# Altium schematic sheet Power Supply.SchDoc("Power Supply")
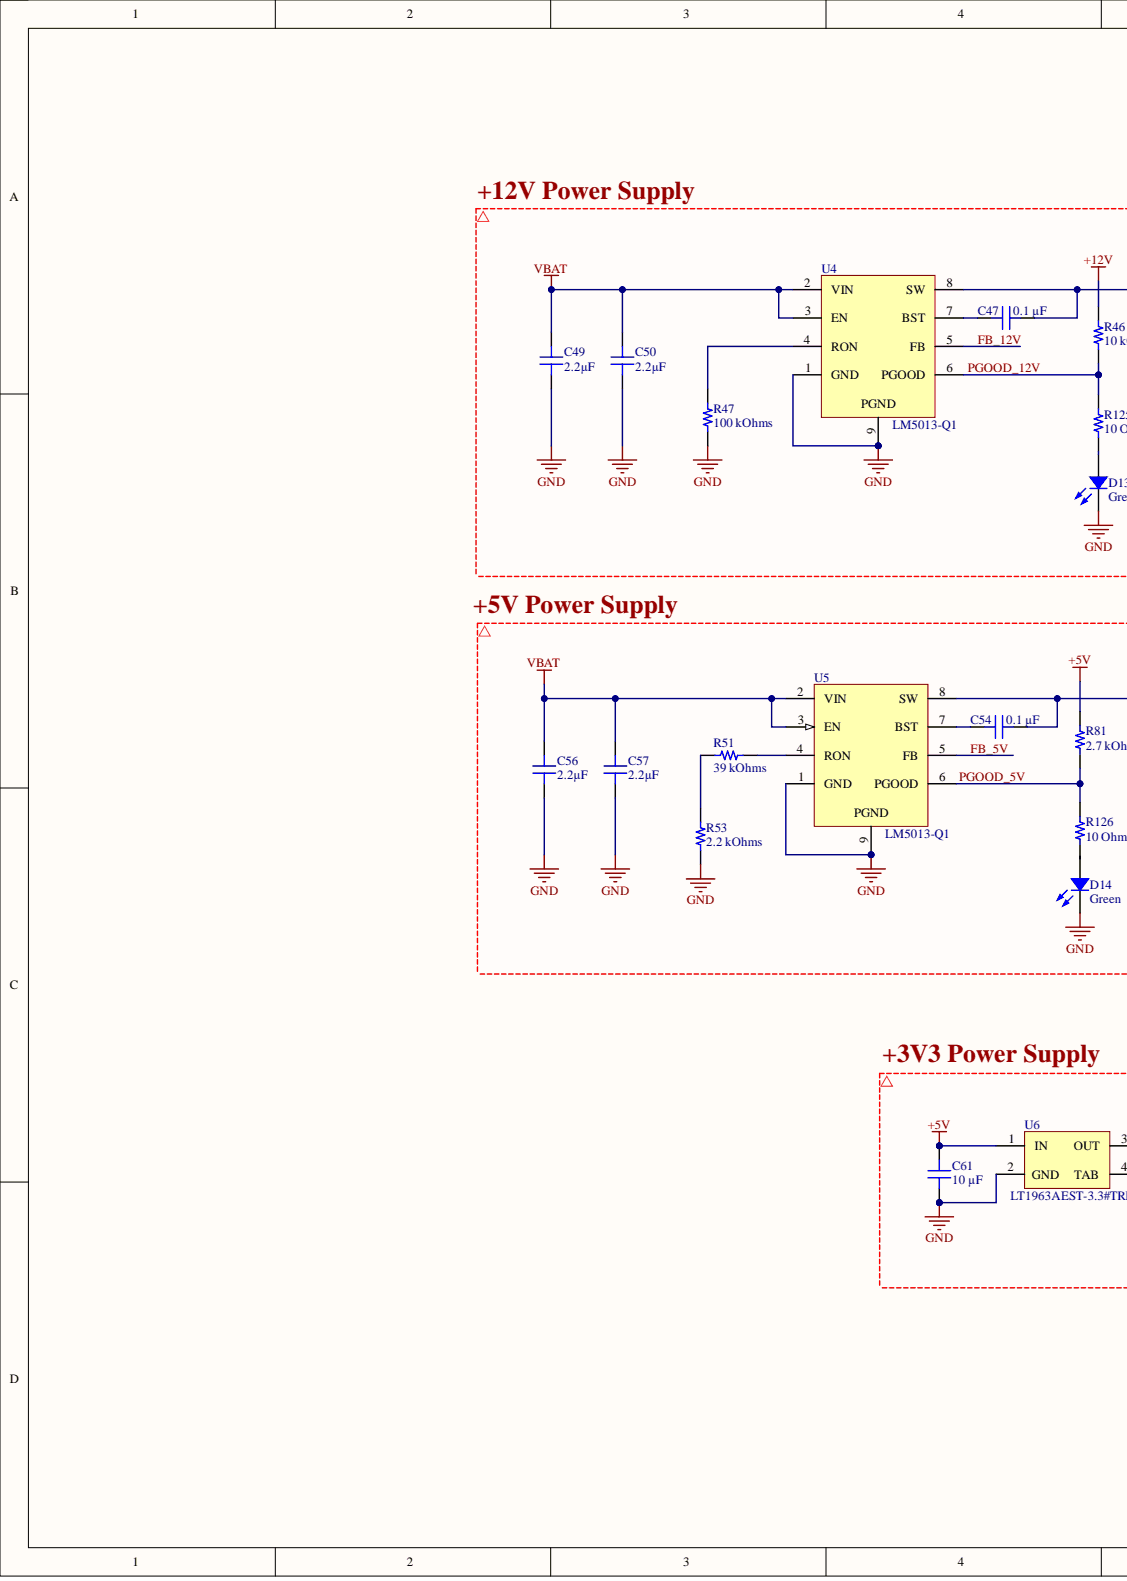
[diagram p0: Power Supply.SchDoc, left]
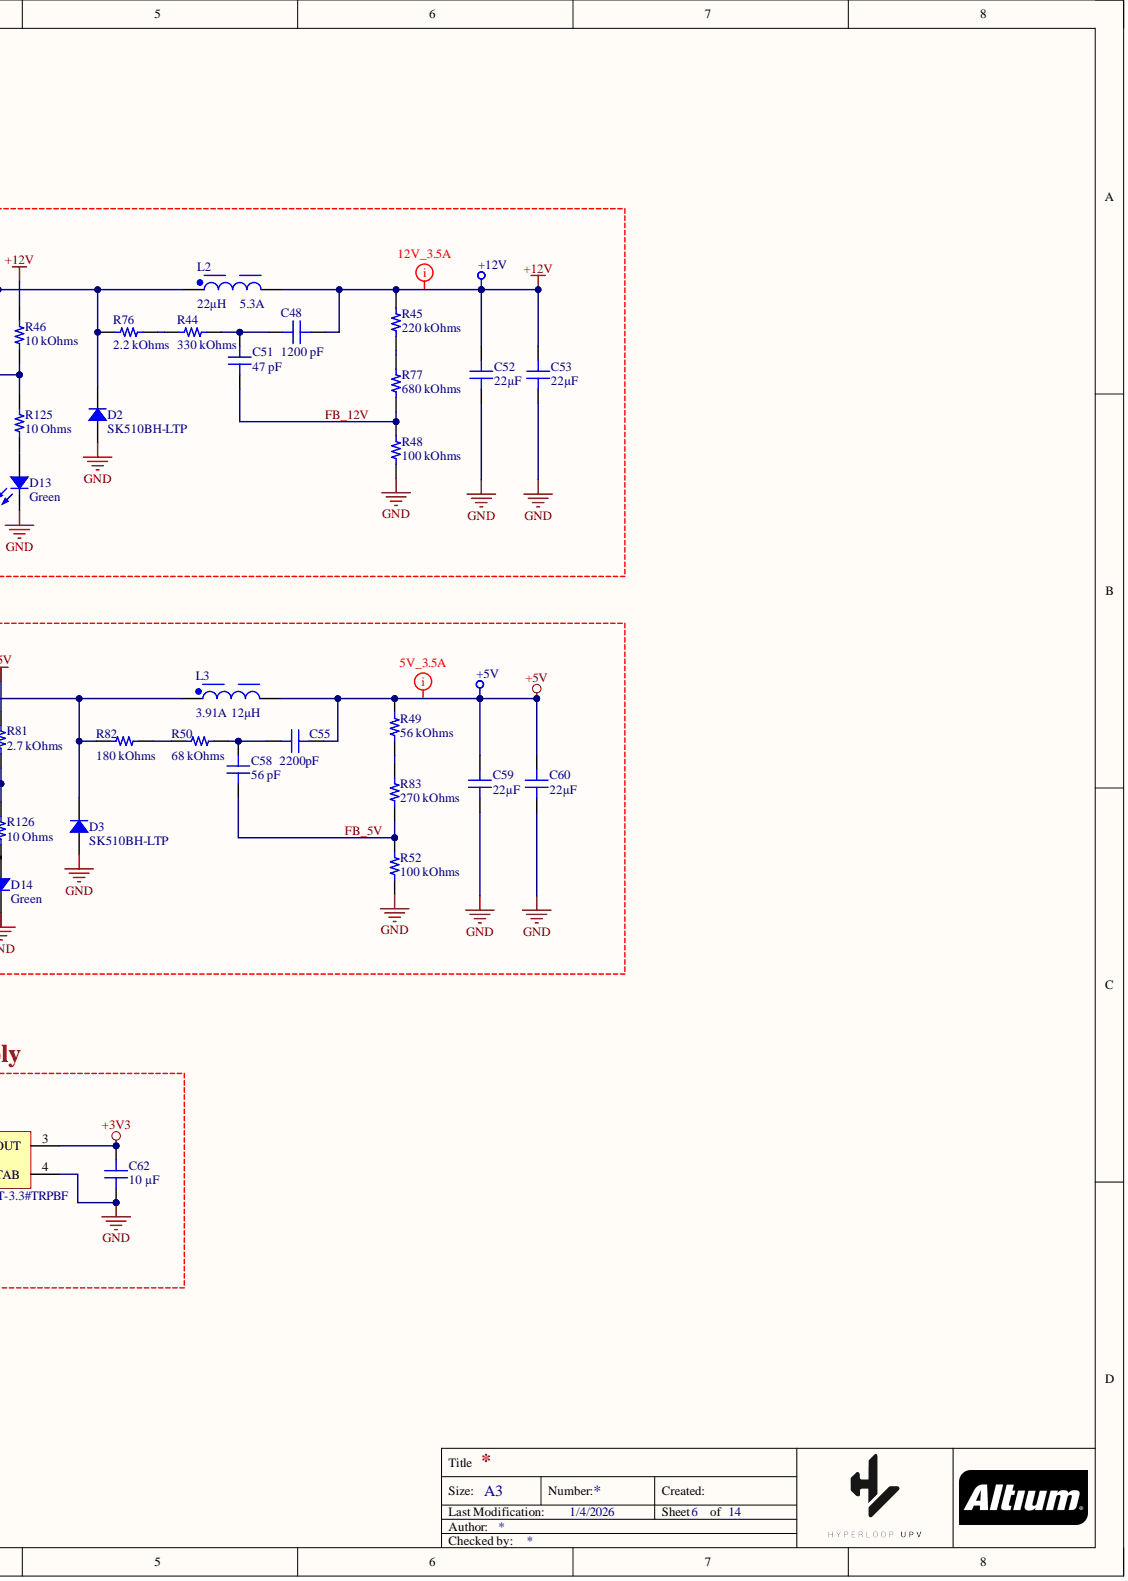
[diagram p1: Power Supply.SchDoc, right]

## Parts
+5V  Test point 1.2mm  (value not drawn)
+12V  Test point 1.2mm  (value not drawn)
C47  0.1 µF
C48  1200 pF
C49  2.2µF
C50  2.2µF
C51  47 pF
C52  22µF
C53  22µF
C54  0.1 µF
C55  2200pF
C56  2.2µF
C57  2.2µF
C58  56 pF
C59  22µF
C60  22µF
C61  10 µF
C62  10 µF
D2  SK510BH-LTP
D3  SK510BH-LTP
D13  Green
D14  Green
L2  Inductance: 22µH  Rated Current: 5.3A  (hidden comment: 7447709220)
L3  Inductance: 12µH  Rated Current: 3.91A  (hidden comment: 744771112)
R44  330 kOhms
R45  220 kOhms
R46  10 kOhms
R47  100 kOhms
R48  100 kOhms
R49  56 kOhms
R50  68 kOhms
R51  39 kOhms
R52  100 kOhms
R53  2.2 kOhms
R76  2.2 kOhms
R77  680 kOhms
R81  2.7 kOhms
R82  180 kOhms
R83  270 kOhms
R125  10 Ohms
R126  10 Ohms
U4  LM5013-Q1
U5  LM5013-Q1
U6  LT1963AEST-3.3#TRPBF

## Nets
+3V3: C62.2 U6.3(OUT)
+5V: +5V.1 C55.2 C59.2 C60.2 C61.2 L3.2 R49.2 R81.2 U6.1(IN)
+12V: +12V.1 C48.2 C52.2 C53.2 L2.2 R45.2 R46.2
GND: C49.1 C50.1 C52.1 C53.1 C56.1 C57.1 C59.1 C60.1 C61.1 C62.1 D2.1 D3.1 D13.2 D14.2 R47.1 R48.1 R52.1 R53.1 U4.1(GND) U4.9 U5.1(GND) U5.9 U6.2(GND) U6.4(TAB)
VBAT: C49.2 C50.2 C56.2 C57.2 U4.2(VIN) U4.3(EN) U5.2(VIN) U5.3(EN)
FB_5V: C58.1 R52.2 R83.1 U5.5(FB)
FB_12V: C51.1 R48.2 R77.1 U4.5(FB)
PGOOD_5V: R81.1 R126.2 U5.6(PGOOD)
PGOOD_12V: R46.1 R125.2 U4.6(PGOOD)
NetC47_1: C47.1 U4.7(BST)
NetC47_2: C47.2 D2.2 L2.1 R76.1 U4.8(SW)
NetC48_1: C48.1 C51.2 R44.2
NetC54_1: C54.1 U5.7(BST)
NetC54_2: C54.2 D3.2 L3.1 R82.1 U5.8(SW)
NetC55_1: C55.1 C58.2 R50.2
NetD13_1: D13.1 R125.1
NetD14_1: D14.1 R126.1
NetR44_1: R44.1 R76.2
NetR45_1: R45.1 R77.2
NetR47_2: R47.2 U4.4(RON)
NetR49_1: R49.1 R83.2
NetR50_1: R50.1 R82.2
NetR51_1: R51.1 U5.4(RON)
NetR51_2: R51.2 R53.2

## Library data of the parts
+5V +12V = CMP-006-00250-2: 1.2mm Test point; Component Kind: Standard; Library Name: Hyperloop UPV
C47 C54 = C0603X104K101T: CAP CER .1UF 100V X7R 0603; manufacturer: Holy Stone Enterprise Co., Ltd.; Database Table Name: Capacitors - Ceramic - 0603; Tolerance: ±10%; Applications: General Purpose; Capacitance: 0.1 µF; Component Kind: Standard; componentlink1description: Datasheet; componentlink1url: https://www.holystonecaps.com/PDF/201612051332320.2017%20MVC%20Series.pdf; componentlink2description: DigiKey Link; componentlink2url: https://www.digikey.com/en/products/detail/holy-stone-enterprise-co-ltd/C0603X104K101T/13152144; Device Package: 0603 (1608 Metric); Library Name: Celestial Altium Library - altium_library.DbLib; Lifecycle Status: Active; Minimum Order: 1; Mounting Type: Surface Mount, MLCC; Operating Temperature: -55°C ~ 125°C; Package / Case: 0603 (1608 Metric); packaging: TapeAndReel; Part Number: C0603X104K101T; Part Status: Active; partid: 34785; price: 0.03; series: MVC; Size / Dimension: 0.063" L x 0.031" W (1.60mm x 0.80mm); Supplier 1: DigiKey; Supplier Part Number 1: 2873-C0603X104K101TCT-ND; Temperature Coefficient: X7R; Thickness (Max): 0.039" (1.00mm); Value: 0.1 µF; Voltage - Rated: 100V
  C47: lastupdated: 19/09/2022 13:00:08
  C54: lastupdated: 2/9/2024 8:09:55 PM
C48 = C0603C122K1RAC7867: CAP CER 1200PF 100V X7R 0603; manufacturer: KEMET; Database Table Name: Capacitors - Ceramic - 0603; Tolerance: ±10%; Applications: Bypass, Decoupling; Capacitance: 1200 pF; Component Kind: Standard; componentlink1description: Datasheet; componentlink1url: https://api.kemet.com/component-edge/download/datasheet/C0603C122K1RACTU.pdf; componentlink2description: DigiKey Link; componentlink2url: https://www.digikey.com/en/products/detail/kemet/C0603C122K1RAC7867/2199865; Device Package: 0603 (1608 Metric); lastupdated: 19/09/2022 13:01:19; Library Name: Celestial Altium Library - altium_library.DbLib; Lifecycle Status: Active; Minimum Order: 1; Mounting Type: Surface Mount, MLCC; Operating Temperature: -55°C ~ 125°C; Package / Case: 0603 (1608 Metric); packaging: TapeAndReel; Part Number: C0603C122K1RAC7867; Part Status: Active; partid: 2381; price: 0.06; series: SMD Comm X7R; Size / Dimension: 0.063" L x 0.031" W (1.60mm x 0.80mm); Supplier 1: DigiKey; Supplier Part Number 1: 399-15358-1-ND; Temperature Coefficient: X7R; Thickness (Max): 0.034" (0.87mm); Value: 1200 pF; Voltage - Rated: 100V
C49 C50 C56 C57 = 12061C225KAT4A: CAP CER 2.2UF 100V X7R 1206; manufacturer: AVX Corporation; Database Table Name: Capacitors - Ceramic - 1206; Tolerance: ±10%; Applications: General Purpose; Capacitance: 2.2µF; Component Kind: Standard; componentlink1description: Datasheet; componentlink1url: http://datasheets.avx.com/X7RDielectric.pdf; componentlink2description: DigiKey Link; componentlink2url: http://digikey.com/product-detail/en/avx-corporation/12061C225KAT4A/478-12481-1-ND/9749333; Device Package: 1206 (3216 Metric); Library Name: Celestial Altium Library - altium_library.DbLib; Lifecycle Status: Active; Minimum Order: 1; Mounting Type: Surface Mount, MLCC; Operating Temperature: -55°C ~ 125°C; Package / Case: 1206 (3216 Metric); packaging: TapeAndReel; Part Number: 12061C225KAT4A; Part Status: Active; partid: 20152; price: 0.13; series: -; Size / Dimension: 0.126" L x 0.063" W (3.20mm x 1.60mm); Supplier 1: DigiKey; Supplier Part Number 1: 478-12481-1-ND; Temperature Coefficient: X7R; Thickness (Max): 0.060" (1.52mm); Value: 2.2µF; Voltage - Rated: 100V
  C49 C50: lastupdated: 02/09/2020 1:05:40
  C56 C57: lastupdated: 9/2/2020 1:05:40 AM
C51 C58 = GQM1875C2E560JB12D: CAP CER 56PF 250V C0G/NP0 0603; manufacturer: Murata Electronics; Database Table Name: Capacitors - Ceramic - 0603; Temperature Coefficient: C0G, NP0; Tolerance: ±5%; Applications: RF, Microwave, High Frequency; Capacitance: 56 pF; Component Kind: Standard; componentlink1description: Datasheet; componentlink1url: https://www.murata.com/~/media/webrenewal/support/library/catalog/products/capacitor/mlcc/c02e.ashx?la=en-us; componentlink2description: DigiKey Link; componentlink2url: https://www.digikey.com/en/products/detail/murata-electronics/GQM1875C2E560JB12D/3900478; Device Package: 0603 (1608 Metric); Features: High Q, Low Loss; lastupdated: 7/20/2024 12:19:27 AM; Library Name: Celestial Altium Library - altium_library.DbLib; Lifecycle Status: Active; Minimum Order: 1; Mounting Type: Surface Mount, MLCC; Operating Temperature: -55°C ~ 125°C; Package / Case: 0603 (1608 Metric); packaging: TapeAndReel; Part Number: GQM1875C2E560JB12D; Part Status: Active; partid: 9090; price: 0.08; series: GQM; Size / Dimension: 0.063" L x 0.031" W (1.60mm x 0.80mm); Supplier 1: DigiKey; Supplier Part Number 1: 490-7175-1-ND; Thickness (Max): 0.031" (0.80mm); Voltage - Rated: 250V
  C51: Value: 47 pF
  C58: Value: 56 pF
C52 C53 C59 C60 = 12063D226KAT2A: CAP CER 22UF 25V X5R 1206; manufacturer: AVX Corporation; Database Table Name: Capacitors - Ceramic - 1206; Tolerance: ±10%; Applications: General Purpose; Capacitance: 22µF; Component Kind: Standard; componentlink1description: Datasheet; componentlink1url: http://datasheets.avx.com/cx5r.pdf; componentlink2description: DigiKey Link; componentlink2url: http://digikey.com/product-detail/en/avx-corporation/12063D226KAT2A/478-9946-1-ND/6149054; Device Package: 1206 (3216 Metric); Library Name: Celestial Altium Library - altium_library.DbLib; Lifecycle Status: Active; Minimum Order: 1; Mounting Type: Surface Mount, MLCC; Operating Temperature: -55°C ~ 85°C; Package / Case: 1206 (3216 Metric); packaging: TapeAndReel; Part Number: 12063D226KAT2A; Part Status: Active; partid: 21243; price: 0.38; series: -; Size / Dimension: 0.126" L x 0.063" W (3.20mm x 1.60mm); Supplier 1: DigiKey; Supplier Part Number 1: 478-9946-1-ND; Temperature Coefficient: X5R; Thickness (Max): 0.071" (1.80mm); Value: 22µF; Voltage - Rated: 25V
  C52 C53: lastupdated: 02/09/2020 1:05:36
  C59 C60: lastupdated: 9/2/2020 1:05:36 AM
C55 = 06035A222JAT2A: CAP CER 2200PF 50V C0G/NP0 0603; manufacturer: AVX Corporation; Database Table Name: Capacitors - Ceramic - 0603; Temperature Coefficient: C0G, NP0; Tolerance: ±5%; Applications: General Purpose; Capacitance: 2200pF; Component Kind: Standard; componentlink1description: Datasheet; componentlink1url: http://datasheets.avx.com/C0GNP0-Dielectric.pdf; componentlink2description: DigiKey Link; componentlink2url: http://digikey.com/product-detail/en/avx-corporation/06035A222JAT2A/478-12698-1-ND/9949232; Device Package: 0603 (1608 Metric); lastupdated: 9/2/2020 1:02:59 AM; Library Name: Celestial Altium Library - altium_library.DbLib; Lifecycle Status: Active; Minimum Order: 1; Mounting Type: Surface Mount, MLCC; Operating Temperature: -55°C ~ 125°C; Package / Case: 0603 (1608 Metric); packaging: TapeAndReel; Part Number: 06035A222JAT2A; Part Status: Active; partid: 18282; price: 0.10; series: -; Size / Dimension: 0.063" L x 0.032" W (1.60mm x 0.81mm); Supplier 1: DigiKey; Supplier Part Number 1: 478-12698-1-ND; Thickness (Max): 0.035" (0.90mm); Value: 2200pF; Voltage - Rated: 50V
C61 C62 = C1206X106J3RACAUTO: CAP CER 10UF 25V X7R 1206; manufacturer: KEMET; Database Table Name: Capacitors - Ceramic - 1206; Tolerance: ±5%; Applications: Automotive, Boardflex Sensitive; Capacitance: 10 µF; Component Kind: Standard; componentlink1description: Datasheet; componentlink1url: https://api.kemet.com/component-edge/download/datasheet/C1206X106J3RACAUTO.pdf; componentlink2description: DigiKey Link; componentlink2url: https://www.digikey.com/en/products/detail/kemet/C1206X106J3RACAUTO/7427566; Device Package: 1206 (3216 Metric); Features: Soft Termination; lastupdated: 6/4/2024 7:10:37 PM; Library Name: Celestial Altium Library - altium_library.DbLib; Lifecycle Status: Active; Minimum Order: 1; Mounting Type: Surface Mount, MLCC; Operating Temperature: -55°C ~ 125°C; Package / Case: 1206 (3216 Metric); packaging: TapeAndReel; Part Number: C1206X106J3RACAUTO; Part Status: Active; partid: 46968; price: 0.43; Ratings: AEC-Q200; series: SMD Auto X7R Flex; Size / Dimension: 0.130" L x 0.063" W (3.30mm x 1.60mm); Supplier 1: DigiKey; Supplier Part Number 1: 399-15736-1-ND; Temperature Coefficient: X7R; Thickness (Max): 0.077" (1.95mm); Value: 10 µF; Voltage - Rated: 25V
D2 D3 = SK510BH-LTP: 5A 100V SCHOTTKY SMB; Manufacturer: Micro Commercial Co; Current - Reverse Leakage @ Vr: 5µA @ 100V; Database Table Name: Diodes - Rectifiers - Single; Speed: Fast Recovery =< 500ns, > 200mA (Io); Voltage - Forward (Vf) (Max) @ If: 850mV @ 5A; Component Kind: Standard; ComponentLink2Description: DigiKey Link; ComponentLink2URL: http://digikey.com/product-detail/en/micro-commercial-co/SK510BH-LTP/353-SK510BH-LTPCT-ND/12177332; Current - Average Rectified (Io): 5A; Diode Type: Schottky; Library Name: Celestial Altium Library - altium_library.DbLib; Lifecycle Status: Active; Minimum Order: 1; Mounting Type: Surface Mount; Package / Case: DO-214AA, SMB; Packaging: TapeAndReel; Part Number: SK510BH-LTP; PartId: 3326; Price: 0.08; Supplier 1: DigiKey; Supplier Device Package: DO-214AA (SMB); Supplier Part Number 1: 353-SK510BH-LTPCT-ND; Voltage - DC Reverse (Vr) (Max): 100V
  D2: LastUpdated: 30/08/2020 20:59:21
  D3: LastUpdated: 8/30/2020 8:59:21 PM
D13 D14 = VLMTG1300-GS08: LED GREEN 0603 SMD; Manufacturer: Vishay Semiconductor Opto Division; Configuration: Standard; Current - Test: 20mA; Database Table Name: LED - Indication; Lens Color: 1.00mm; Lens Size: 1.10mm x 0.80mm; Lens Style: Rectangle with Flat Top; Millicandela Rating: 260.5mcd; Viewing Angle: 130°; Voltage - Forward (Vf) (Typ): 3.2V; Wavelength - Dominant: 525nm; Wavelength - Peak: 530nm; Color: Green; Component Kind: Standard; ComponentLink1Description: Datasheet; ComponentLink1URL: http://www.vishay.com/docs/82437/vlmo1300.pdf; ComponentLink2Description: DigiKey Link; ComponentLink2URL: https://www.digikey.com/en/products/detail/vishay-semiconductor-opto-division/VLMTG1300-GS08/2813351; Device Package: 0603 (1608 Metric); Height (Max): 1.00mm; LastUpdated: 19/09/2022 13:49:58; Library Name: Celestial Altium Library - altium_library.DbLib; Lifecycle Status: Active; Minimum Order: 1; Mounting Type: Surface Mount; Package / Case: 0603 (1608 Metric); Packaging: TapeAndReel; Part Number: VLMTG1300-GS08; Part Status: Active; PartId: 609; Price: 0.08; Series: CHIPLED; Size / Dimension: 1.60mm L x 0.80mm W; Supplier 1: DigiKey; Supplier Device Package: 0603; Supplier Part Number 1: VLMTG1300-GS08CT-ND; Value: Green
L2 = CMP-006-00206-1: WE-PD SMT Power Inductor, size 1210, 22µH, 5.3A, 28mO; Manufacturer: Wurth Elektronik; DC Resistance: 23mO; Saturation Current: 6.5A; Self Resonant Frequency: 10MHz; Type: Standard; Case/Package: 1210; Category: Shielded Power Inductors; Component Kind: Standard; Component Type: Standard; ComponentLink1Description: Website Link; ComponentLink1URL: https://www.we-online.com/redexpert/article/7447709220?ad; ComponentLink2Description: Datasheet Link; ComponentLink2URL: https://www.we-online.com/redexpert/spec/7447709220?ad; DC Resistance Max: 28mO; Design Item ID: 7447709220; Height: 10mm; Length: 12mm; Manufacturer Part Number: 7447709220; Match Code: WE-PD; Mount: Surface Mount; Operating Temperature Max: 125°C; Operating Temperature Min: -40°C; Packaging: Tape and Reel; Pins: 2; Source: WE-PD.SchLib; Width: 12mm; Library Name: Hyperloop UPV
L3 = CMP-006-00200-1: WE-PD SMT Power Inductor, size 1260, 12µH, 3.91A, 27mO; Manufacturer: Wurth Elektronik; DC Resistance: 23mO; Saturation Current: 4.85A; Self Resonant Frequency: 19.7MHz; Type: Standard; Case/Package: 1260; Category: Shielded Power Inductors; Component Kind: Standard; Component Type: Standard; ComponentLink1Description: Website Link; ComponentLink1URL: https://www.we-online.com/redexpert/article/744771112?ad; ComponentLink2Description: Datasheet Link; ComponentLink2URL: https://www.we-online.com/redexpert/spec/744771112?ad; DC Resistance Max: 27mO; Design Item ID: 744771112; Height: 6mm; Length: 12mm; Manufacturer Part Number: 744771112; Match Code: WE-PD; Mount: Surface Mount; Operating Temperature Max: 125°C; Operating Temperature Min: -40°C; Packaging: Tape and Reel; Pins: 2; Source: WE-PD.SchLib; Width: 12mm; Library Name: Hyperloop UPV
R44 R76 = RK73H1JTTD3323F: RES 332K OHM 1% 1/10W 0603; Manufacturer: KOA Speer Electronics, Inc.; Database Table Name: Resistors - Surface Mount - 0603; Power (Watts): 0.1W, 1/10W; Resistance: 332 kOhms; Temperature Coefficient: ±100ppm/°C; Component Kind: Standard; ComponentLink1Description: Datasheet; ComponentLink1URL: http://www.koaspeer.com/catimages/Products/RK73H/RK73H.pdf; ComponentLink2Description: DigiKey Link; ComponentLink2URL: https://www.digikey.com/en/products/detail/koa-speer-electronics-inc/RK73H1JTTD3323F/9844963; Composition: Thick Film; Device Package: 0603 (1608 Metric); Features: Automotive AEC-Q200; Height - Seated (Max): 0.022" (0.55mm); LastUpdated: 7/25/2024 9:43:09 AM; Library Name: Celestial Altium Library - altium_library.DbLib; Lifecycle Status: Active; Minimum Order: 1; Number of Terminations: 2; Operating Temperature: -55°C ~ 155°C; Package / Case: 0603 (1608 Metric); Packaging: CutTape; Part Number: RK73H1JTTD3323F; Part Status: Active; PartId: 6126; Price: 0.01; Ratings: AEC-Q200; Series: RK73H; Size / Dimension: 0.063" L x 0.031" W (1.60mm x 0.80mm); Supplier 1: DigiKey; Supplier Device Package: 0603; Supplier Part Number 1: 2019-RK73H1JTTD3323FCT-ND; Tolerance: ±1%
  R44: Value: 330 kOhms
  R76: Value: 2.2 kOhms
R45 R77 = CRCW0603909KFKEA: RES SMD 909K OHM 1% 1/10W 0603; Manufacturer: Vishay Dale; Database Table Name: Resistors - Surface Mount - 0603; Power (Watts): 0.1W, 1/10W; Resistance: 909 kOhms; Temperature Coefficient: ±100ppm/°C; Component Kind: Standard; ComponentLink1Description: Datasheet; ComponentLink1URL: http://www.vishay.com/docs/20035/dcrcwe3.pdf; ComponentLink2Description: DigiKey Link; ComponentLink2URL: https://www.digikey.com/en/products/detail/vishay-dale/CRCW0603909KFKEA/1175005; Composition: Thick Film; Device Package: 0603 (1608 Metric); Features: Automotive AEC-Q200; Height - Seated (Max): 0.020" (0.50mm); LastUpdated: 2/9/2024 8:43:24 PM; Library Name: Celestial Altium Library - altium_library.DbLib; Lifecycle Status: Active; Minimum Order: 1; Number of Terminations: 2; Operating Temperature: -55°C ~ 155°C; Package / Case: 0603 (1608 Metric); Packaging: TapeAndReel; Part Number: CRCW0603909KFKEA; Part Status: Active; PartId: 8009; Price: 0.01; Ratings: AEC-Q200; Series: CRCW; Size / Dimension: 0.061" L x 0.033" W (1.55mm x 0.85mm); Supplier 1: DigiKey; Supplier Device Package: 0603; Supplier Part Number 1: 541-909KHCT-ND; Tolerance: ±1%
  R45: Value: 220 kOhms
  R77: Value: 680 kOhms
R46 = RT0603FRE0710KL: RES SMD 10K OHM 1% 1/10W 0603; Manufacturer: Yageo; Database Table Name: Resistors - Surface Mount - 0603; Power (Watts): 0.1W, 1/10W; Temperature Coefficient: ±50ppm/°C; Component Kind: Standard; ComponentLink1Description: Datasheet; ComponentLink1URL: https://www.yageo.com/upload/media/product/productsearch/datasheet/rchip/PYu-RT_1-to-0.01_RoHS_L_11.pdf; ComponentLink2Description: DigiKey Link; ComponentLink2URL: https://www.digikey.com/en/products/detail/yageo/RT0603FRE0710KL/1075040; Composition: Thin Film; Device Package: 0603 (1608 Metric); Height - Seated (Max): 0.022" (0.55mm); LastUpdated: 7/25/2024 9:19:32 AM; Library Name: Celestial Altium Library - altium_library.DbLib; Lifecycle Status: Active; Minimum Order: 1; Number of Terminations: 2; Operating Temperature: -55°C ~ 155°C; Package / Case: 0603 (1608 Metric); Packaging: TapeAndReel; Part Number: RT0603FRE0710KL; Part Status: Active; PartId: 5671; Price: 0.01; Resistance: 10 kOhms; Series: RT; Size / Dimension: 0.063" L x 0.031" W (1.60mm x 0.80mm); Supplier 1: DigiKey; Supplier Device Package: 0603; Supplier Part Number 1: YAG2321CT-ND; Tolerance: ±1%; Value: 10 kOhms
R47 R48 R52 = RNCS0603BKE100K: RES 100K OHM 0.1% 1/16W 0603; Manufacturer: Stackpole Electronics Inc; Database Table Name: Resistors - Surface Mount - 0603; Power (Watts): 0.063W, 1/16W; Temperature Coefficient: ±25ppm/°C; Component Kind: Standard; ComponentLink1Description: Datasheet; ComponentLink1URL: https://www.seielect.com/catalog/sei-rncs_rnch.pdf; ComponentLink2Description: DigiKey Link; ComponentLink2URL: https://www.digikey.com/en/products/detail/stackpole-electronics-inc/RNCS0603BKE100K/1708835; Composition: Thin Film; Device Package: 0603 (1608 Metric); Features: Anti-Corrosive, Automotive AEC-Q200, Moisture Resistant; Height - Seated (Max): 0.022" (0.55mm); LastUpdated: 2/9/2024 8:43:37 PM; Library Name: Celestial Altium Library - altium_library.DbLib; Lifecycle Status: Active; Minimum Order: 1; Number of Terminations: 2; Operating Temperature: -55°C ~ 155°C; Package / Case: 0603 (1608 Metric); Packaging: TapeAndReel; Part Number: RNCS0603BKE100K; Part Status: Active; PartId: 4849; Price: 0.06; Ratings: AEC-Q200; Resistance: 100 kOhms; Series: RNCS; Size / Dimension: 0.061" L x 0.031" W (1.55mm x 0.80mm); Supplier 1: DigiKey; Supplier Device Package: 0603; Supplier Part Number 1: RNCS0603BKE100KCT-ND; Tolerance: ±0.1%; Value: 100 kOhms
R49 R83 = CRCW0603316KFKEA: RES SMD 316K OHM 1% 1/10W 0603; Manufacturer: Vishay Dale; Database Table Name: Resistors - Surface Mount - 0603; Power (Watts): 0.1W, 1/10W; Resistance: 316 kOhms; Temperature Coefficient: ±100ppm/°C; Component Kind: Standard; ComponentLink1Description: Datasheet; ComponentLink1URL: http://www.vishay.com/docs/20035/dcrcwe3.pdf; ComponentLink2Description: DigiKey Link; ComponentLink2URL: https://www.digikey.com/en/products/detail/vishay-dale/CRCW0603316KFKEA/1174951; Composition: Thick Film; Device Package: 0603 (1608 Metric); Features: Automotive AEC-Q200; Height - Seated (Max): 0.020" (0.50mm); LastUpdated: 2/9/2024 8:43:25 PM; Library Name: Celestial Altium Library - altium_library.DbLib; Lifecycle Status: Active; Minimum Order: 1; Number of Terminations: 2; Operating Temperature: -55°C ~ 155°C; Package / Case: 0603 (1608 Metric); Packaging: TapeAndReel; Part Number: CRCW0603316KFKEA; Part Status: Active; PartId: 7837; Price: 0.01; Ratings: AEC-Q200; Series: CRCW; Size / Dimension: 0.061" L x 0.033" W (1.55mm x 0.85mm); Supplier 1: DigiKey; Supplier Device Package: 0603; Supplier Part Number 1: 541-316KHCT-ND; Tolerance: ±1%
  R49: Value: 56 kOhms
  R83: Value: 270 kOhms
R50 R82 = ERA-3AEB2493V: RES SMD 249K OHM 0.1% 1/10W 0603; Manufacturer: Panasonic Electronic Components; Database Table Name: Resistors - Surface Mount - 0603; Power (Watts): 0.1W, 1/10W; Resistance: 249 kOhms; Temperature Coefficient: ±25ppm/°C; Component Kind: Standard; ComponentLink1Description: Datasheet; ComponentLink1URL: https://industrial.panasonic.com/cdbs/www-data/pdf/RDM0000/AOA0000C307.pdf; ComponentLink2Description: DigiKey Link; ComponentLink2URL: https://www.digikey.com/en/products/detail/panasonic-electronic-components/ERA-3AEB2493V/2026962; Composition: Thin Film; Device Package: 0603 (1608 Metric); Features: Automotive AEC-Q200; Height - Seated (Max): 0.022" (0.55mm); LastUpdated: 2/9/2024 8:43:34 PM; Library Name: Celestial Altium Library - altium_library.DbLib; Lifecycle Status: Active; Minimum Order: 1; Number of Terminations: 2; Operating Temperature: -55°C ~ 155°C; Package / Case: 0603 (1608 Metric); Packaging: TapeAndReel; Part Number: ERA-3AEB2493V; Part Status: Active; PartId: 5578; Price: 0.03; Ratings: AEC-Q200; Series: ERA-3A; Size / Dimension: 0.063" L x 0.031" W (1.60mm x 0.80mm); Supplier 1: DigiKey; Supplier Device Package: 0603; Supplier Part Number 1: P249KDBCT-ND; Tolerance: ±0.1%
  R50: Value: 68 kOhms
  R82: Value: 180 kOhms
R51 R53 = CR0603-FX-4122ELF: RES SMD 41.2K OHM 1% 1/10W 0603; Manufacturer: Bourns Inc.; Database Table Name: Resistors - Surface Mount - 0603; Power (Watts): 0.1W, 1/10W; Resistance: 41.2 kOhms; Temperature Coefficient: ±100ppm/°C; Component Kind: Standard; ComponentLink1Description: Datasheet; ComponentLink1URL: https://www.bourns.com/docs/Product-Datasheets/CHPREZTR.pdf; ComponentLink2Description: DigiKey Link; ComponentLink2URL: https://www.digikey.com/en/products/detail/bourns-inc/CR0603-FX-4122ELF/3784014; Composition: Thick Film; Device Package: 0603 (1608 Metric); Features: Moisture Resistant; Height - Seated (Max): 0.022" (0.55mm); LastUpdated: 2/9/2024 8:43:30 PM; Library Name: Celestial Altium Library - altium_library.DbLib; Lifecycle Status: Active; Minimum Order: 1; Number of Terminations: 2; Operating Temperature: -55°C ~ 155°C; Package / Case: 0603 (1608 Metric); Packaging: CutTape; Part Number: CR0603-FX-4122ELF; Part Status: Active; PartId: 6408; Price: 0.01; Series: CR0603; Size / Dimension: 0.063" L x 0.031" W (1.60mm x 0.80mm); Supplier 1: DigiKey; Supplier Device Package: 0603; Supplier Part Number 1: CR0603-FX-4122ELFCT-ND; Tolerance: ±1%
  R51: Value: 39 kOhms
  R53: Value: 2.2 kOhms
R81 R125 R126 = RT0603FRE0710KL: RES SMD 10K OHM 1% 1/10W 0603; Manufacturer: Yageo; Database Table Name: Resistors - Surface Mount - 0603; Power (Watts): 0.1W, 1/10W; Resistance: 10 kOhms; Temperature Coefficient: ±50ppm/°C; Component Kind: Standard; ComponentLink1Description: Datasheet; ComponentLink1URL: https://www.yageo.com/upload/media/product/productsearch/datasheet/rchip/PYu-RT_1-to-0.01_RoHS_L_11.pdf; ComponentLink2Description: DigiKey Link; ComponentLink2URL: https://www.digikey.com/en/products/detail/yageo/RT0603FRE0710KL/1075040; Composition: Thin Film; Device Package: 0603 (1608 Metric); Height - Seated (Max): 0.022" (0.55mm); LastUpdated: 7/25/2024 9:19:32 AM; Library Name: Celestial Altium Library - altium_library.DbLib; Lifecycle Status: Active; Minimum Order: 1; Number of Terminations: 2; Operating Temperature: -55°C ~ 155°C; Package / Case: 0603 (1608 Metric); Packaging: TapeAndReel; Part Number: RT0603FRE0710KL; Part Status: Active; PartId: 5671; Price: 0.01; Series: RT; Size / Dimension: 0.063" L x 0.031" W (1.60mm x 0.80mm); Supplier 1: DigiKey; Supplier Device Package: 0603; Supplier Part Number 1: YAG2321CT-ND; Tolerance: ±1%
  R81: Value: 2.7 kOhms
  R125 R126: Value: 10 Ohms
U4 = LM5013-Q1-2: 100-V Input, 3.5-A Non-Synchronous Buck DC/DC Converter with Ultra-low IQ; Component Kind: Standard; Library Name: Hyperloop UPV
U5 = LM5013-Q1-1: Component Kind: Standard; Library Name: Hyperloop UPV
U6 = LT1963AEST-3.3#TRPBF-1: Fixed Positive LDO Regulator, 3.3V, 0.55V Dropout, BIPolar, PDSO3; Component Kind: Standard; Library Name: Hyperloop UPV
